# Altium schematic sheet UBX_NORA_B1_SCHEM_0005_Interface_Isolation.SchDoc
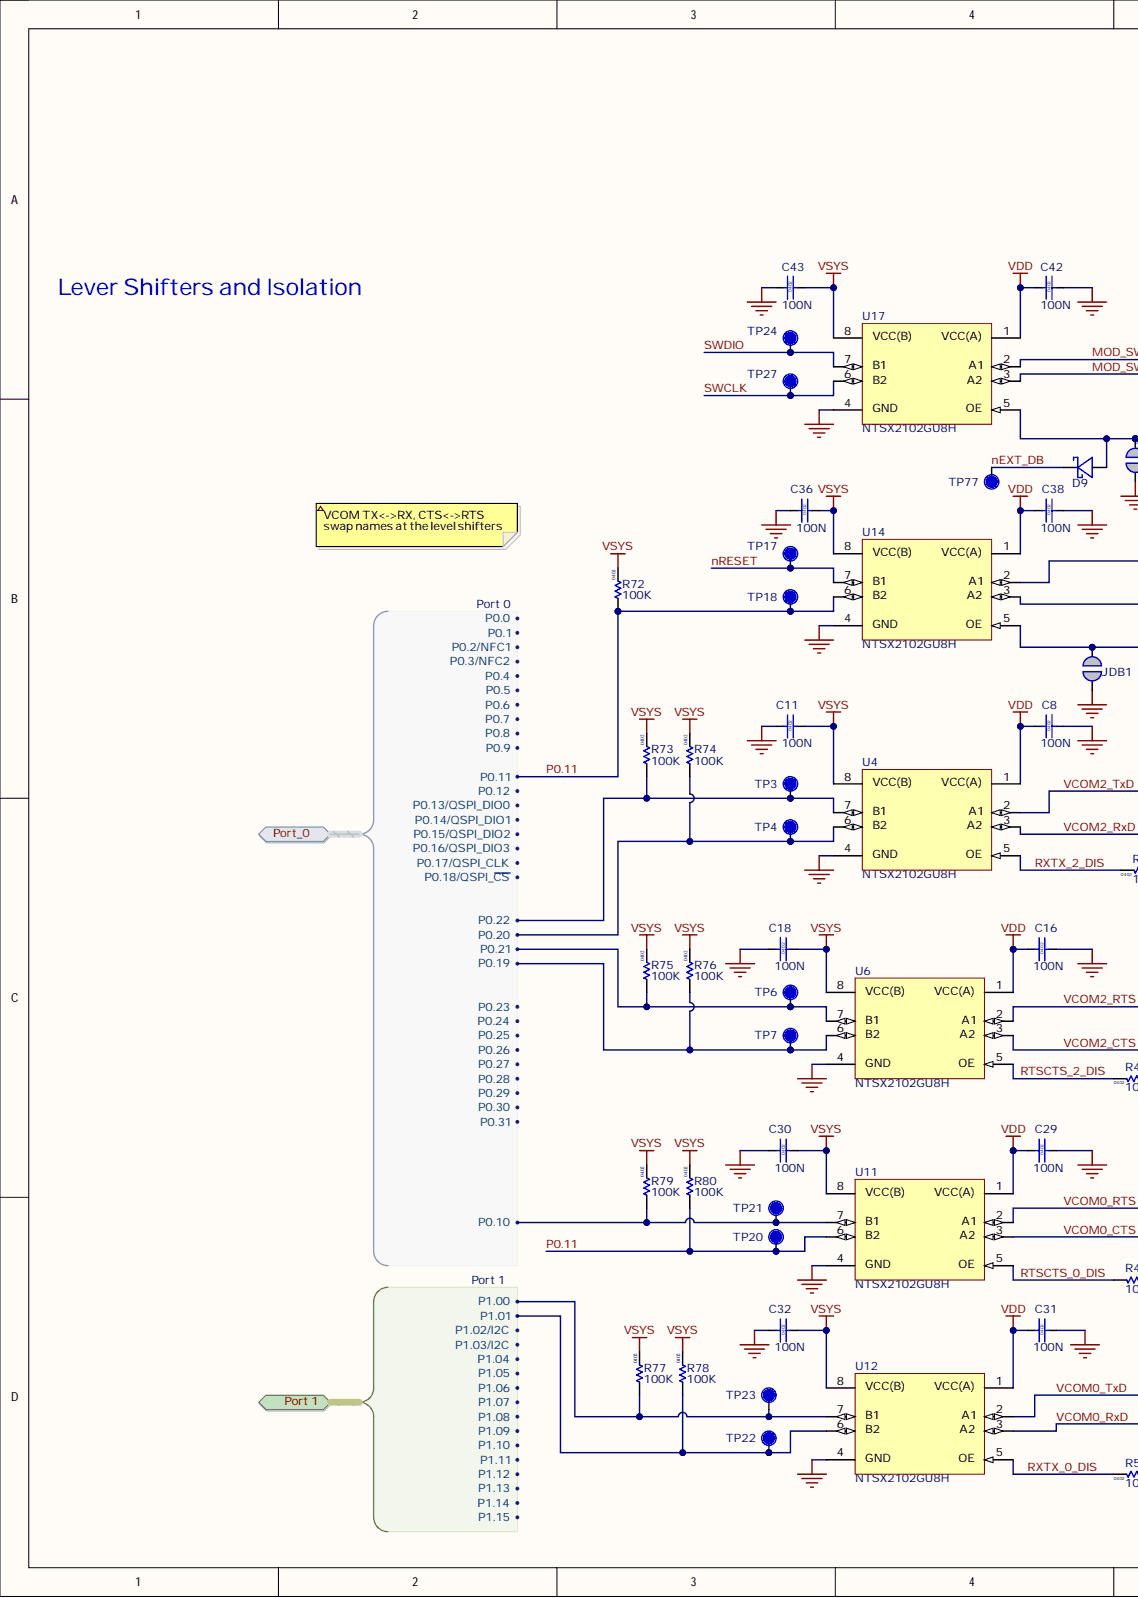
[diagram p0: UBX_NORA_B1_SCHEM_0005_Interface_Isolation.SchDoc, left]
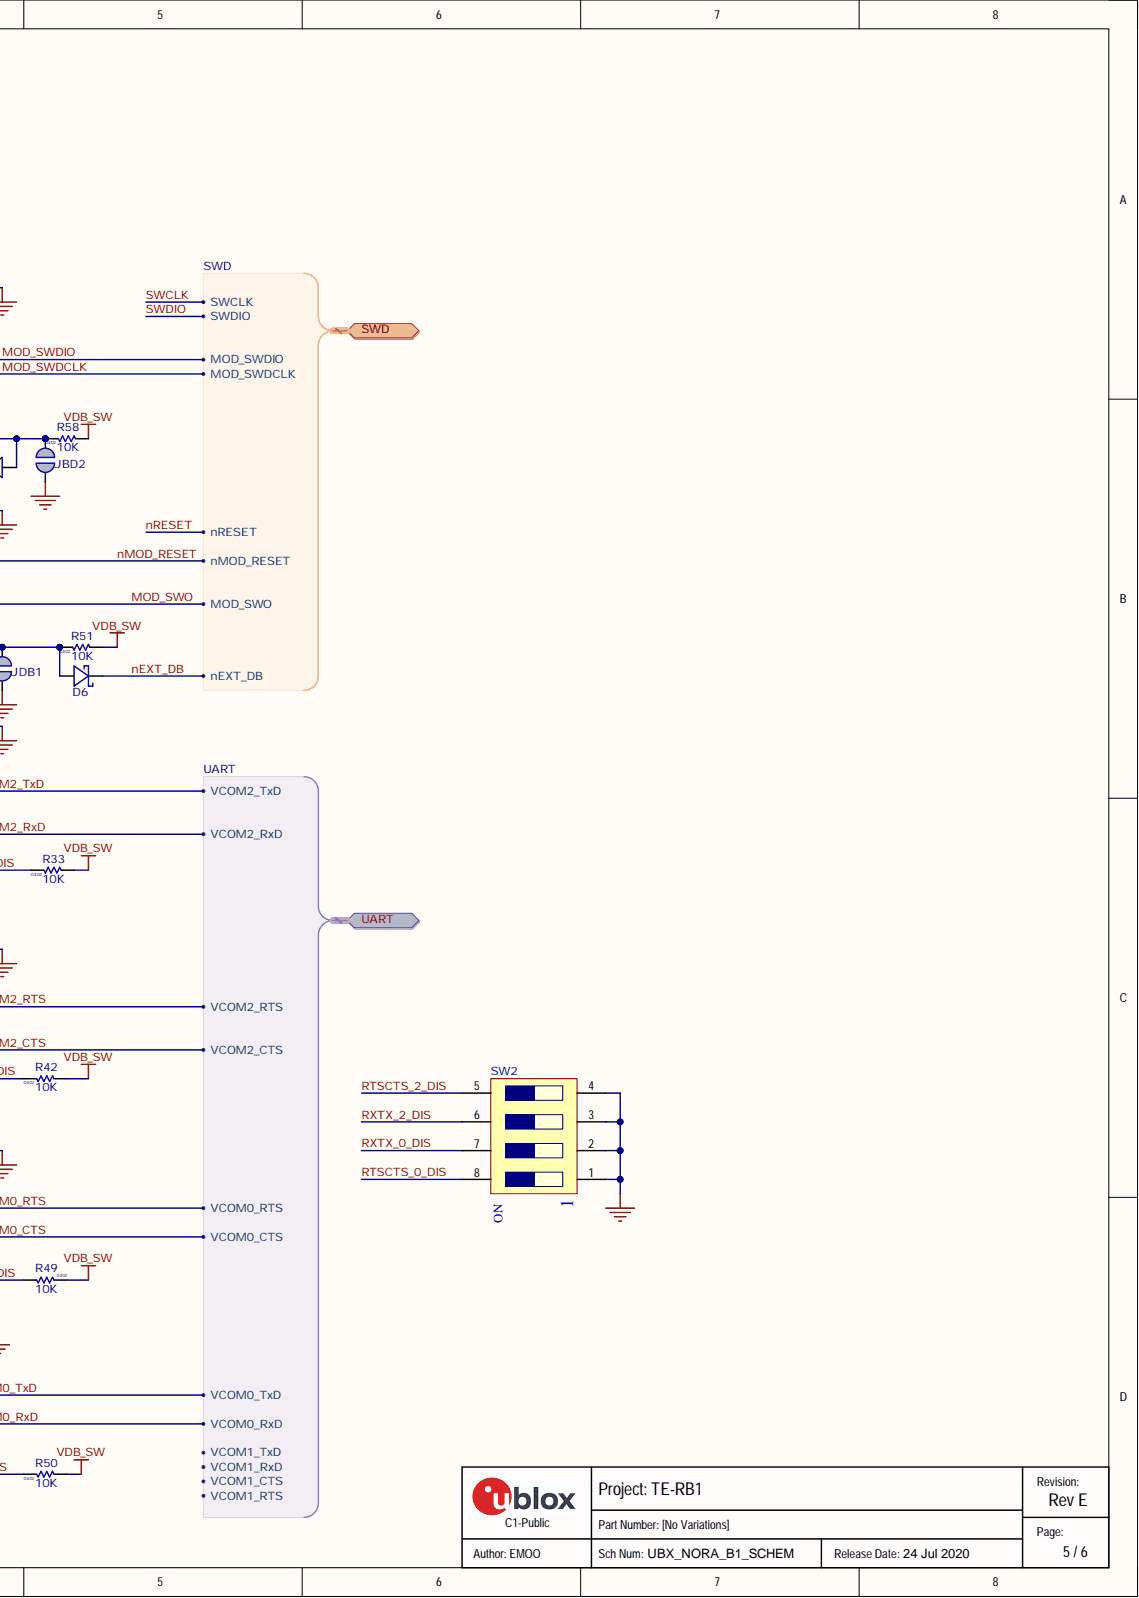
[diagram p1: UBX_NORA_B1_SCHEM_0005_Interface_Isolation.SchDoc, right]

## Parts
C8  value 100N  Size: 0402  [Cap 0402 HD]  (hidden comment: UBXH01-2021002100N)
C11  value 100N  Size: 0402  [Cap 0402 HD]  (hidden comment: UBXH01-2021002100N)
C16  value 100N  Size: 0402  [Cap 0402 HD]  (hidden comment: UBXH01-2021002100N)
C18  value 100N  Size: 0402  [Cap 0402 HD]  (hidden comment: UBXH01-2021002100N)
C29  value 100N  Size: 0402  [Cap 0402 HD]  (hidden comment: UBXH01-2021002100N)
C30  value 100N  Size: 0402  [Cap 0402 HD]  (hidden comment: UBXH01-2021002100N)
C31  value 100N  Size: 0402  [Cap 0402 HD]  (hidden comment: UBXH01-2021002100N)
C32  value 100N  Size: 0402  [Cap 0402 HD]  (hidden comment: UBXH01-2021002100N)
C36  value 100N  Size: 0402  [Cap 0402 HD]  (hidden comment: UBXH01-2021002100N)
C38  value 100N  Size: 0402  [Cap 0402 HD]  (hidden comment: UBXH01-2021002100N)
C42  value 100N  Size: 0402  [Cap 0402 HD]  (hidden comment: UBXH01-2021002100N)
C43  value 100N  Size: 0402  [Cap 0402 HD]  (hidden comment: UBXH01-2021002100N)
D6  UBXH07-0000039  [SOD-523]  (value not drawn)
D9  UBXH07-0000039  [SOD-523]  (value not drawn)
JBD2  UBXH00-0000082  [Solderbridge-Open]  (value not drawn)  (no BOM)
JDB1  UBXH00-0000082  [Solderbridge-Open]  (value not drawn)  (no BOM)
R33  value 10K  Size: 0402  [RES 0402 HD]  (hidden comment: UBXH18-000006510K)
R42  value 10K  Size: 0402  [RES 0402 HD]  (hidden comment: UBXH18-000006510K)
R49  value 10K  Size: 0402  [RES 0402 HD]  (hidden comment: UBXH18-000006510K)
R50  value 10K  Size: 0402  [RES 0402 HD]  (hidden comment: UBXH18-000006510K)
R51  value 10K  Size: 0402  [RES 0402 HD]  (hidden comment: UBXH18-000006510K)
R58  value 10K  Size: 0402  [RES 0402 HD]  (hidden comment: UBXH18-000006510K)
R72  value 100K  Size: 0402  [RES 0402 HD]  (hidden comment: UBXH18-0000005100K)
R73  value 100K  Size: 0402  [RES 0402 HD]  (hidden comment: UBXH18-0000005100K)
R74  value 100K  Size: 0402  [RES 0402 HD]  (hidden comment: UBXH18-0000005100K)
R75  value 100K  Size: 0402  [RES 0402 HD]  (hidden comment: UBXH18-0000005100K)
R76  value 100K  Size: 0402  [RES 0402 HD]  (hidden comment: UBXH18-0000005100K)
R77  value 100K  Size: 0402  [RES 0402 HD]  (hidden comment: UBXH18-0000005100K)
R78  value 100K  Size: 0402  [RES 0402 HD]  (hidden comment: UBXH18-0000005100K)
R79  value 100K  Size: 0402  [RES 0402 HD]  (hidden comment: UBXH18-0000005100K)
R80  value 100K  Size: 0402  [RES 0402 HD]  (hidden comment: UBXH18-0000005100K)
SW2  UBXH19-0000055  [SOP1.27P6.2x6.2-8Pin]  (value not drawn)
TP3  TP  [TP10R]
TP4  TP  [TP10R]
TP6  TP  [TP10R]
TP7  TP  [TP10R]
TP17  TP  [TP10R]
TP18  TP  [TP10R]
TP20  TP  [TP10R]
TP21  TP  [TP10R]
TP22  TP  [TP10R]
TP23  TP  [TP10R]
TP24  TP  [TP10R]
TP27  TP  [TP10R]
TP77  TP  [TP10R]
U4  Manufacturer Part Number 1: NTSX2102GU8H  [QFN0.4P3x1-8Pin]  (hidden comment: UBXH10-0000106)
U6  Manufacturer Part Number 1: NTSX2102GU8H  [QFN0.4P3x1-8Pin]  (hidden comment: UBXH10-0000106)
U11  Manufacturer Part Number 1: NTSX2102GU8H  [QFN0.4P3x1-8Pin]  (hidden comment: UBXH10-0000106)
U12  Manufacturer Part Number 1: NTSX2102GU8H  [QFN0.4P3x1-8Pin]  (hidden comment: UBXH10-0000106)
U14  Manufacturer Part Number 1: NTSX2102GU8H  [QFN0.4P3x1-8Pin]  (hidden comment: UBXH10-0000106)
U17  Manufacturer Part Number 1: NTSX2102GU8H  [QFN0.4P3x1-8Pin]  (hidden comment: UBXH10-0000106)

## Ports
Port 1, Port 1.P1.00, Port 1.P1.01, Port 1.P1.02/I2C, Port 1.P1.03/I2C, Port 1.P1.04, Port 1.P1.05, Port 1.P1.06, Port 1.P1.07, Port 1.P1.08, Port 1.P1.09, Port 1.P1.10, Port 1.P1.11, Port 1.P1.12, Port 1.P1.13, Port 1.P1.14, Port 1.P1.15, Port_0, Port_0.P0.0, Port_0.P0.1, Port_0.P0.2/NFC1, Port_0.P0.3/NFC2, Port_0.P0.4, Port_0.P0.5, Port_0.P0.6, Port_0.P0.7, Port_0.P0.8, Port_0.P0.9, Port_0.P0.10, Port_0.P0.11, Port_0.P0.12, Port_0.P0.13/QSPI_DIO0, Port_0.P0.14/QSPI_DIO1, Port_0.P0.15/QSPI_DIO2, Port_0.P0.16/QSPI_DIO3, Port_0.P0.17/QSPI_CLK, Port_0.P0.18/QSPI_~{CS}, Port_0.P0.19, Port_0.P0.20, Port_0.P0.21, Port_0.P0.22, Port_0.P0.23, Port_0.P0.24, Port_0.P0.25, Port_0.P0.26, Port_0.P0.27, Port_0.P0.28, Port_0.P0.29, Port_0.P0.30, Port_0.P0.31, SWD, SWD.MOD_SWDCLK, SWD.MOD_SWDIO, SWD.MOD_SWO, SWD.nEXT_DB, SWD.nMOD_RESET, SWD.nRESET, SWD.SWCLK, SWD.SWDIO, UART, UART.VCOM0_CTS, UART.VCOM0_RTS, UART.VCOM0_RxD, UART.VCOM0_TxD, UART.VCOM1_CTS, UART.VCOM1_RTS, UART.VCOM1_RxD, UART.VCOM1_TxD, UART.VCOM2_CTS, UART.VCOM2_RTS, UART.VCOM2_RxD, UART.VCOM2_TxD

## Nets
GND: C8.2 C11.1 C16.2 C18.1 C29.2 C30.1 C31.2 C32.1 C36.1 C38.2 C42.2 C43.1 JBD2.1 JDB1.1 SW2.1 SW2.2 SW2.3 SW2.4 U4.4(GND) U6.4(GND) U11.4(GND) U12.4(GND) U14.4(GND) U17.4(GND)
VDB_SW: R33.1 R42.1 R49.2 R50.1 R51.1 R58.1
VDD: C8.1 C16.1 C29.1 C31.1 C38.1 C42.1 U4.1(VCC(A)) U6.1(VCC(A)) U11.1(VCC(A)) U12.1(VCC(A)) U14.1(VCC(A)) U17.1(VCC(A))
VSYS: C11.2 C18.2 C30.2 C32.2 C36.2 C43.2 R72.2 R73.2 R74.2 R75.2 R76.2 R77.2 R78.2 R79.2 R80.2 U4.8(VCC(B)) U6.8(VCC(B)) U11.8(VCC(B)) U12.8(VCC(B)) U14.8(VCC(B)) U17.8(VCC(B))
MOD_SWDCLK: U17.3(A2) ; port SWD
MOD_SWDIO: U17.2(A1) ; port SWD
MOD_SWO: U14.3(A2) ; port SWD
nEXT_DB: D6.C D9.C TP77.1 ; port SWD
nMOD_RESET: U14.2(A1) ; port SWD
nRESET: TP17.1 U14.7(B1) ; port SWD
P0.11: R72.1 R80.1 TP18.1 TP20.1 U11.6(B2) U14.6(B2) ; port Port_0
RTSCTS_0_DIS: R49.1 SW2.8 U11.5(OE)
RTSCTS_2_DIS: R42.2 SW2.5 U6.5(OE)
RXTX_0_DIS: R50.2 SW2.7 U12.5(OE)
RXTX_2_DIS: R33.2 SW2.6 U4.5(OE)
SWCLK: TP27.1 U17.6(B2) ; port SWD
SWDIO: TP24.1 U17.7(B1) ; port SWD
VCOM0_CTS: U11.3(A2) ; port UART
VCOM0_RTS: U11.2(A1) ; port UART
VCOM0_RxD: U12.3(A2) ; port UART
VCOM0_TxD: U12.2(A1) ; port UART
VCOM2_CTS: U6.3(A2) ; port UART
VCOM2_RTS: U6.2(A1) ; port UART
VCOM2_RxD: U4.3(A2) ; port UART
VCOM2_TxD: U4.2(A1) ; port UART
NetD6_A: D6.A JDB1.2 R51.2 U14.5(OE)
NetD9_A: D9.A JBD2.2 R58.2 U17.5(OE)
NetR73_1: R73.1 TP3.1 U4.7(B1) ; port Port_0
NetR74_1: R74.1 TP4.1 U4.6(B2) ; port Port_0
NetR75_1: R75.1 TP6.1 U6.7(B1) ; port Port_0
NetR76_1: R76.1 TP7.1 U6.6(B2) ; port Port_0
NetR77_1: R77.1 TP23.1 U12.7(B1) ; port Port 1
NetR78_1: R78.1 TP22.1 U12.6(B2) ; port Port 1
NetR79_1: R79.1 TP21.1 U11.7(B1) ; port Port_0
N00001: ; port Port_0
N00002: ; port Port_0
N00003: ; port Port_0
N00004: ; port Port_0
N00005: ; port Port_0
N00006: ; port Port_0
N00007: ; port Port_0
N00008: ; port Port_0
N00009: ; port Port_0
N00010: ; port Port_0
N00011: ; port Port_0
N00012: ; port Port_0
N00013: ; port Port_0
N00014: ; port Port_0
N00015: ; port Port_0
N00016: ; port Port_0
N00017: ; port Port_0
N00018: ; port Port_0
N00019: ; port Port_0
N00020: ; port Port_0
N00021: ; port Port_0
N00022: ; port Port_0
N00023: ; port Port_0
N00024: ; port Port_0
N00025: ; port Port_0
N00026: ; port Port_0
N00027: ; port UART
N00028: ; port UART
N00029: ; port UART
N00030: ; port UART
N00031: ; port Port 1
N00032: ; port Port 1
N00033: ; port Port 1
N00034: ; port Port 1
N00035: ; port Port 1
N00036: ; port Port 1
N00037: ; port Port 1
N00038: ; port Port 1
N00039: ; port Port 1
N00040: ; port Port 1
N00041: ; port Port 1
N00042: ; port Port 1
N00043: ; port Port 1
N00044: ; port Port 1

## Library data of the parts
C8 C11 C16 C18 C29-C32 C36 C38 C42 C43 = UBXH01-2021002: CAP CER X5R 0402 100N 10% 10V; Manufacturer: Murata Manufacturing Company, Ltd.; Manufacturer P/N: GRM155R61A104KA01; Database Table Name: Passive - Capacitor; Editor: roland.rust; Env: GREEN; Mfg P/N Released: Yes; Mfg P/N Split: 100; Mfg P/N Status: NRND; Perferred: PREF; Permitted Use: Volume Products; Component Kind: Standard; Library Name: UBX_Parts_DB_Lib.DbLib; Manufacturer 2: Samsung Electro-Mechanics; Manufacturer P/N 2: CL05A104KP5NNN; Max Voltage: 10V; Mfg P/N Datasheet 2: https://wiki.u-blox.com/bin/view/Generic/Docs/DocumentSummary?index=28113; Mfg P/N Released 2: Yes; Mfg P/N Split 2: 0; Mfg P/N Status 2: Active; Part Number: UBXH01-2021002; Part Type: Capacitor; RefDes: C; Release Data: 2005-07-22T13:59:44; Signal Integrity: Cap Semi; Simulation: CAP; Tolerance: 10%; UBX Wiki: https://wiki.u-blox.com/bin/view/Operations/PartDetails?index=5759; Released by: roland.rust
D6 D9 = UBXH07-0000039: SCHOTTKY BARRIER DIODE 30V 200mA; Manufacturer: ON Semiconductor; Manufacturer P/N: RB521S30T1G; Database Table Name: Discrete - Diode; Editor: matej.budin; Env: ROHS; Mfg P/N Released: Yes; Mfg P/N Split: 100; Mfg P/N Status: Active; Perferred: PREF; Permitted Use: Volume Products; Released by: pierpaolo.arban; Value: RB521S30T1G; Component Kind: Standard; Library Name: UBX_Parts_DB_Lib.DbLib; Max Current: 200mA; Max Voltage: 30V; Mfg P/N Datasheet: https://wiki.u-blox.com/bin/view/Generic/Docs/DocumentSummary?index=22755; Part Number: UBXH07-0000039; Part Type: Diode; RefDes: D; Release Data: 2015-06-18T10:04:48; UBX Wiki: https://wiki.u-blox.com/bin/view/Operations/PartDetails?index=22753
JBD2 JDB1 = UBXH00-0000082: SOLDERBRIDGE-OPEN; Database Table Name: Printed Circuit Element - Connector; Editor: aneeb.sohail; Env: GREEN; Perferred: PREF; Permitted Use: Volume Products; Library Name: UBX_Parts_DB_Lib.DbLib; Max Operating Temp: 155°C; Min Operating Temp: -55°C; Part Number: UBXH00-0000082; Part Type: Connector; RefDes: J; UBX Wiki: https://wiki.u-blox.com/bin/view/Operations/PartDetails?index=36361
R33 R42 R49-R51 R58 = UBXH18-0000065: RES THICK FILM CHIP 0402 10K 1% 63mW; Manufacturer: KOA; Manufacturer P/N: RK73H1ETTP1002F; Database Table Name: Passive - Resistor; Editor: erian.bezhani; Env: ROHS; Mfg P/N Released: Yes; Mfg P/N Split: 100; Mfg P/N Status: Active; Perferred: PREF; Permitted Use: Volume Products; Released by: massimo.vlacci; Component Kind: Standard; Library Name: UBX_Parts_DB_Lib.DbLib; Max Power: 63mW; Mfg P/N Datasheet: https://wiki.u-blox.com/bin/view/Generic/Docs/DocumentSummary?index=8222; Part Number: UBXH18-0000065; Part Type: Resistor; RefDes: R; Release Data: 2012-06-08T18:02:21; Signal Integrity: Res; Simulation: RESISTOR; Tolerance: 1%; UBX Wiki: https://wiki.u-blox.com/bin/view/Operations/PartDetails?index=16837
R72-R80 = UBXH18-0000005: RES THICK FILM CHIP 0402 100K 1% 63mW; Manufacturer: KOA; Manufacturer P/N: RK73H1ETTP1003F; Database Table Name: Passive - Resistor; Editor: dario.antonaz; Env: ROHS; Mfg P/N Released: Yes; Mfg P/N Split: 100; Mfg P/N Status: Active; Permitted Use: Volume Products; Released by: sandro.sestan; Component Kind: Standard; Library Name: UBX_Parts_DB_Lib.DbLib; Manufacturer 2: ROHM; Manufacturer P/N 2: MCR01MZPF1003; Max Power: 63mW; Mfg P/N Datasheet: https://wiki.u-blox.com/bin/view/Generic/Docs/DocumentSummary?index=8222; Mfg P/N Datasheet 2: https://wiki.u-blox.com/bin/view/Generic/Docs/DocumentSummary?index=8186; Mfg P/N Released 2: Yes; Mfg P/N Split 2: 0; Mfg P/N Status 2: Active; Part Number: UBXH18-0000005; Part Type: Resistor; Perferred: N/A; RefDes: R; Release Data: 2009-11-30T11:05:22; Signal Integrity: Res; Simulation: RESISTOR; Tolerance: 1%; UBX Wiki: https://wiki.u-blox.com/bin/view/Operations/PartDetails?index=8114
SW2 = UBXH19-0000055: DIP SWITCH QUAD SPST SLIDE SMT 24V 25mA; Database Table Name: Electromechanical - Switch; Editor: eric.moog; Env: ROHS; Perferred: PREF; Permitted Use: Support Products; Component Kind: Standard; Library Name: UBX_Parts_DB_Lib.DbLib; Max Current: 25mA; Max Operating Temp: 85°C; Max Voltage: 24V; Min Operating Temp: -40°C; Part Number: UBXH19-0000055; Part Type: Switch; RefDes: S; UBX Wiki: https://wiki.u-blox.com/bin/view/Operations/PartDetails?index=38926
TP3 TP4 TP6 TP7 TP17 TP18 TP20-TP24 TP27 TP77 = UBXH00-0000096: TESTPOINT 1MM CIRCULAR; Database Table Name: Printed Circuit Element - Testpoint; Editor: eric.moog; Perferred: PREF; Permitted Use: Volume Products; Component Kind: Standard; Env: N/A; Library Name: UBX_Parts_DB_Lib.DbLib; Max Operating Temp: 125°C; Min Operating Temp: -55°C; Part Number: UBXH00-0000096; Part Type: Testpoint; RefDes: TP; UBX Wiki: https://wiki.u-blox.com/bin/view/Operations/PartDetails?index=38784
U4 U6 U11 U12 U14 U17 = UBXH10-0000106: 2-BIT BIDIRECTIONAL TRANSLATING TRANSCEIVER AUTO DIRECTION SENSING OPEN DRAIN XQFN8 5.5V; Manufacturer: NXP; Manufacturer 1: NXP Semiconductors; Manufacturer P/N: NTSX2102GU8; Part Name: IC TXRX TRANSLATING 8XQFN; Part_Type: Digital Logic; Body Size: 1.4mm X 1.2mm; Component Mounting Type: SMT; Database Table Name: IC - Interface; Editor: eric.moog; Env: ROHS; Logic Type: Level Translator; Mfg P/N Released: No; Mfg P/N Split: 100; Mfg P/N Status: Active; MFG Package: XFQFN-8; Number of Circuits: 1; Perferred: PREF; Permitted Use: Volume Products; SMT Pitch: 0.4mm; Use in new designs: Yes; Value: NTSX2102GU8; Component Kind: Standard; Height: 0.5mm; Library Name: UBX_Parts_DB_Lib.DbLib; Max Operating Temp: 85°C; Max Voltage: 5.5V; Mfg P/N Datasheet: https://wiki.u-blox.com/bin/view/Generic/Docs/DocumentSummary?index=38756; Min Operating Temp: -40°C; Package Type: QFN; Part Number: UBXH10-0000106; Part Type: Interface; Pins: 8; RefDes: U; UBX Wiki: https://wiki.u-blox.com/bin/view/Operations/PartDetails?index=38826; Voltage: 5.5V
